# Altium schematic sheet LED Ring.SchDoc
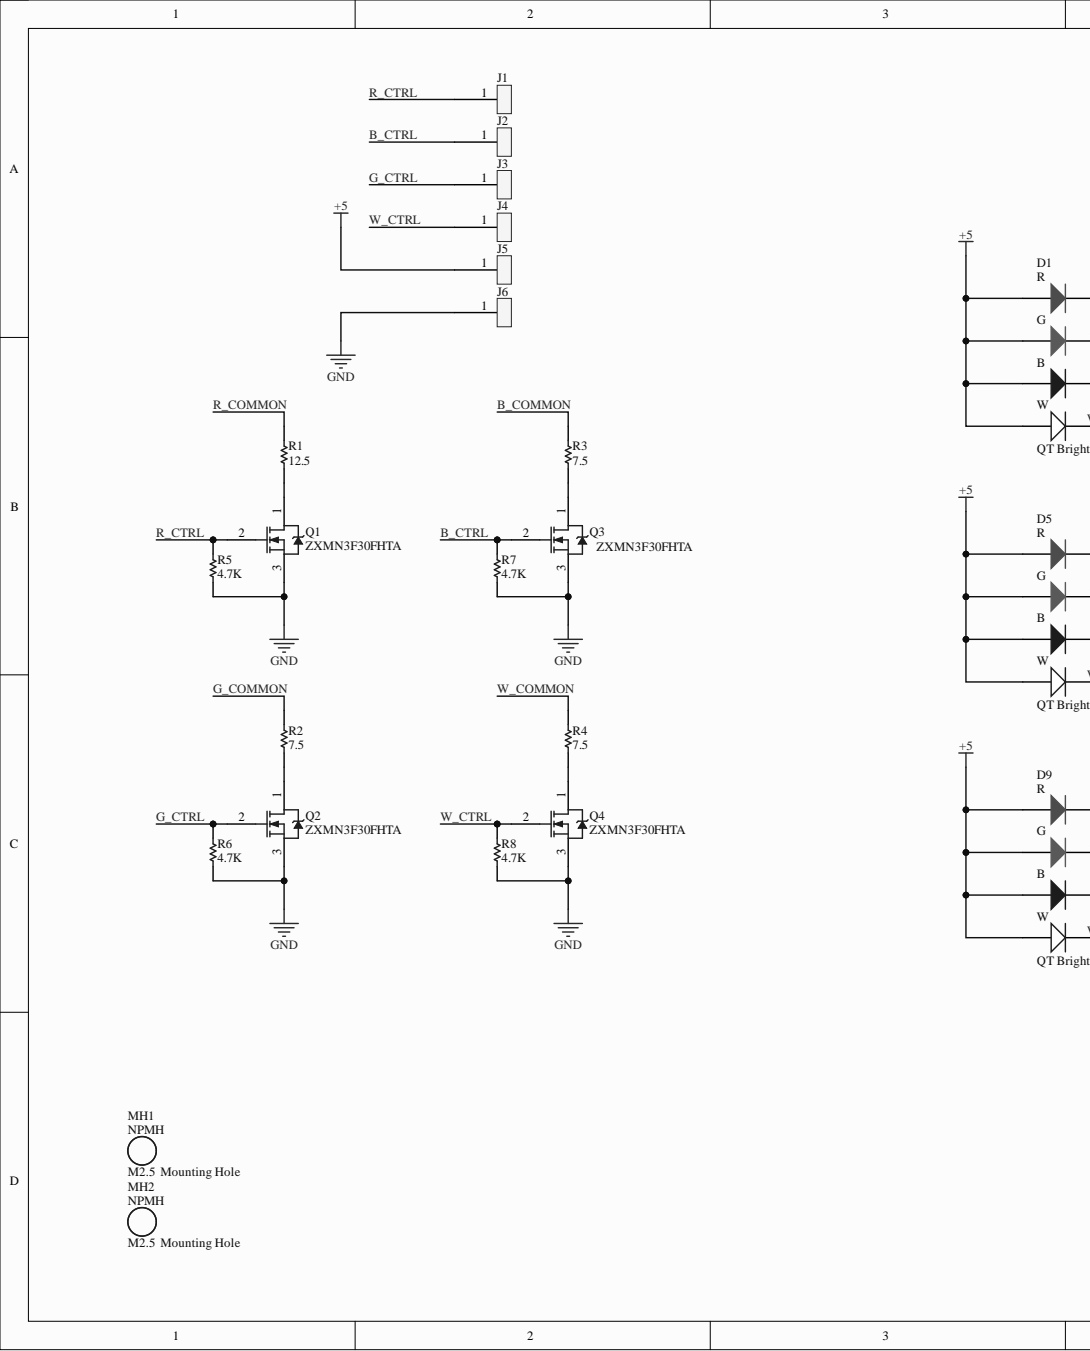
[diagram p0: LED Ring.SchDoc, left]
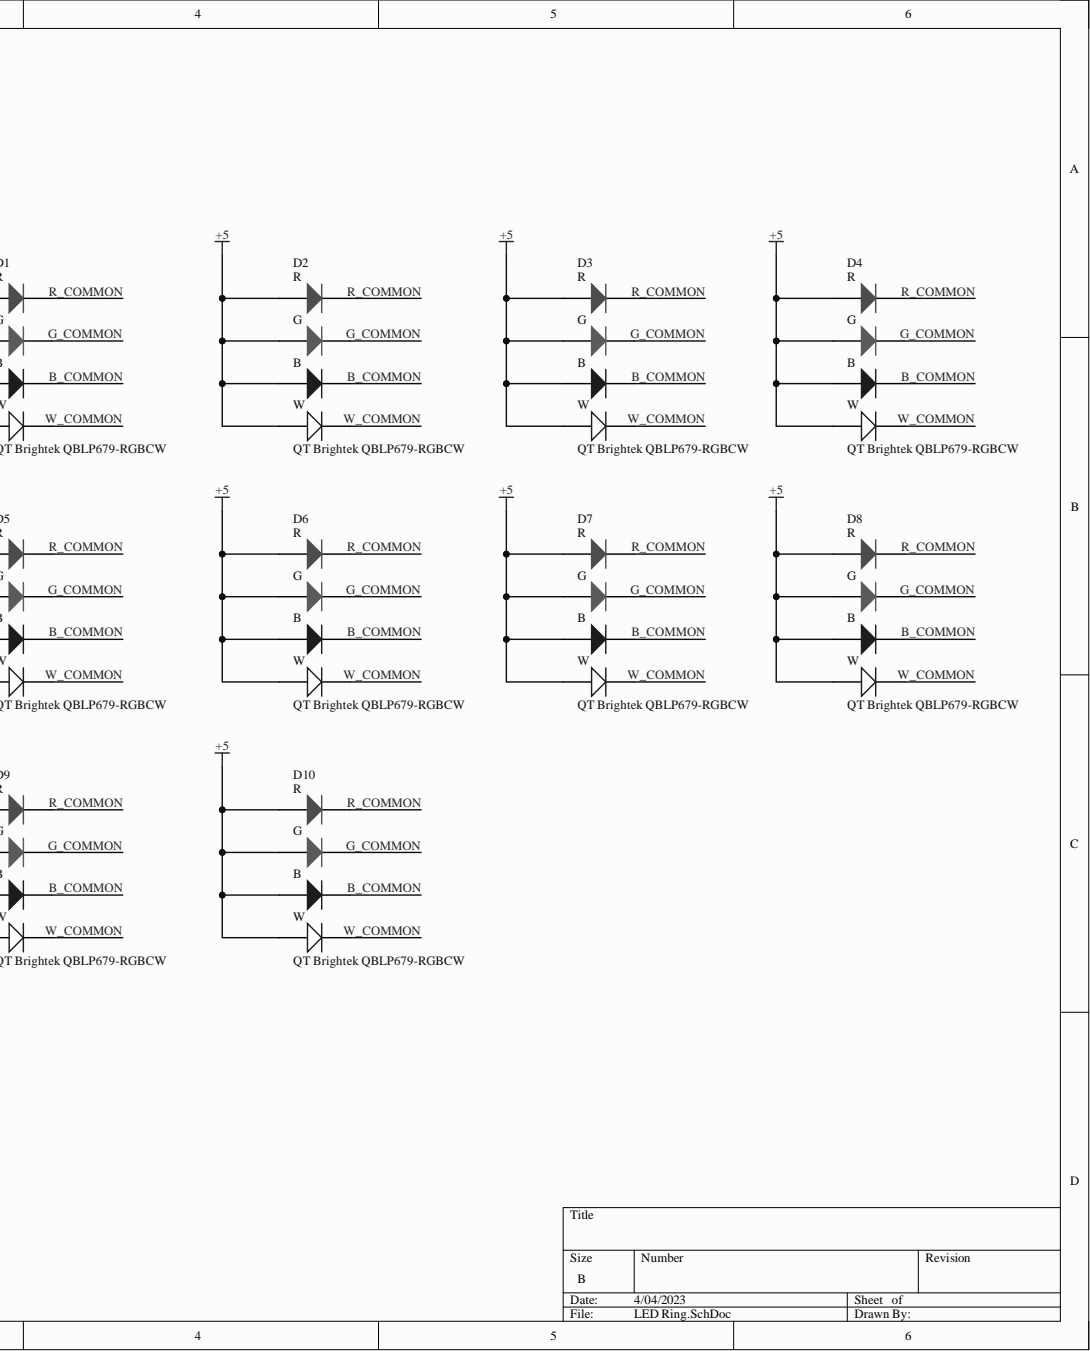
[diagram p1: LED Ring.SchDoc, right]

## Parts
D1  QT Brightek QBLP679-RGBCW  Manufacturer Part Number: QBLP679-RGBCW  [QT Brightek QBLP679-RGBCW-Footprint-1]
D2  QT Brightek QBLP679-RGBCW  Manufacturer Part Number: QBLP679-RGBCW  [QT Brightek QBLP679-RGBCW-Footprint-1]
D3  QT Brightek QBLP679-RGBCW  Manufacturer Part Number: QBLP679-RGBCW  [QT Brightek QBLP679-RGBCW-Footprint-1]
D4  QT Brightek QBLP679-RGBCW  Manufacturer Part Number: QBLP679-RGBCW  [QT Brightek QBLP679-RGBCW-Footprint-1]
D5  QT Brightek QBLP679-RGBCW  Manufacturer Part Number: QBLP679-RGBCW  [QT Brightek QBLP679-RGBCW-Footprint-1]
D6  QT Brightek QBLP679-RGBCW  Manufacturer Part Number: QBLP679-RGBCW  [QT Brightek QBLP679-RGBCW-Footprint-1]
D7  QT Brightek QBLP679-RGBCW  Manufacturer Part Number: QBLP679-RGBCW  [QT Brightek QBLP679-RGBCW-Footprint-1]
D8  QT Brightek QBLP679-RGBCW  Manufacturer Part Number: QBLP679-RGBCW  [QT Brightek QBLP679-RGBCW-Footprint-1]
D9  QT Brightek QBLP679-RGBCW  Manufacturer Part Number: QBLP679-RGBCW  [QT Brightek QBLP679-RGBCW-Footprint-1]
D10  QT Brightek QBLP679-RGBCW  Manufacturer Part Number: QBLP679-RGBCW  [QT Brightek QBLP679-RGBCW-Footprint-1]
J1  Solder Pad  [Solder Pad-Footprint-2]  (value not drawn)
J2  Solder Pad  [Solder Pad-Footprint-2]  (value not drawn)
J3  Solder Pad  [Solder Pad-Footprint-2]  (value not drawn)
J4  Solder Pad  [Solder Pad-Footprint-2]  (value not drawn)
J5  Solder Pad  [Solder Pad-Footprint-2]  (value not drawn)
J6  Solder Pad  [Solder Pad-Footprint-2]  (value not drawn)
MH1  M2.5  Mounting Hole  [M2.5  Mounting Hole-Footprint-1]
MH2  M2.5  Mounting Hole  [M2.5  Mounting Hole-Footprint-1]
Q1  ZXMN3F30FHTA  [PCB-6qjdqzw9o1g00o09vodq-1]
Q2  ZXMN3F30FHTA  [PCB-6qjdqzw9o1g00o09vodq-1]
Q3  ZXMN3F30FHTA  [PCB-6qjdqzw9o1g00o09vodq-1]
Q4  ZXMN3F30FHTA  [PCB-6qjdqzw9o1g00o09vodq-1]
R1  12.5  [PCB-0002-00354-1]
R2  7.5  [PCB-0002-00354-1]
R3  7.5  [PCB-0002-00354-1]
R4  7.5  [PCB-0002-00354-1]
R5  4.7K  [PCB-0002-00354-1]
R6  4.7K  [PCB-0002-00354-1]
R7  4.7K  [PCB-0002-00354-1]
R8  4.7K  [PCB-0002-00354-1]

## Nets
+5: D1.5 D1.6 D1.7 D1.8 D2.5 D2.6 D2.7 D2.8 D3.5 D3.6 D3.7 D3.8 D4.5 D4.6 D4.7 D4.8 D5.5 D5.6 D5.7 D5.8 D6.5 D6.6 D6.7 D6.8 D7.5 D7.6 D7.7 D7.8 D8.5 D8.6 D8.7 D8.8 D9.5 D9.6 D9.7 D9.8 D10.5 D10.6 D10.7 D10.8 J5.1
GND: J6.1 Q1.3 Q2.3 Q3.3 Q4.3 R5.1 R6.1 R7.1 R8.1
B_COMMON: D1.2 D2.2 D3.2 D4.2 D5.2 D6.2 D7.2 D8.2 D9.2 D10.2 R3.2
B_CTRL: J2.1 Q3.2 R7.2
G_COMMON: D1.3 D2.3 D3.3 D4.3 D5.3 D6.3 D7.3 D8.3 D9.3 D10.3 R2.2
G_CTRL: J3.1 Q2.2 R6.2
R_COMMON: D1.4 D2.4 D3.4 D4.4 D5.4 D6.4 D7.4 D8.4 D9.4 D10.4 R1.2
R_CTRL: J1.1 Q1.2 R5.2
W_COMMON: D1.1 D2.1 D3.1 D4.1 D5.1 D6.1 D7.1 D8.1 D9.1 D10.1 R4.2
W_CTRL: J4.1 Q4.2 R8.2
NetQ1_1: Q1.1 R1.1
NetQ2_1: Q2.1 R2.1
NetQ3_1: Q3.1 R3.1
NetQ4_1: Q4.1 R4.1

## Library data of the parts
D1 = CMP-81b54e06759c5e72-5: Led Rgbw Diffused 8PLCC SMD; ReplaceComponentId: NLGCQQLC; Component Kind: Standard; Digikey Part Number: 1516-QBLP679-RGBCWCT-ND; Digikey Url: https://www.digikey.com/en/products/detail/qt-brightek-qtb/QBLP679-RGBCW/13278847; Library Name: My Personal Space; Octopart Url: https://octopart.com/qblp679-rgbcw-qt+brightek-112841039; OctopartID: 81b54e06759c5e72; PartId: 1
D2 = CMP-81b54e06759c5e72-5: Led Rgbw Diffused 8PLCC SMD; ReplaceComponentId: LNENNGUC; Component Kind: Standard; Digikey Part Number: 1516-QBLP679-RGBCWCT-ND; Digikey Url: https://www.digikey.com/en/products/detail/qt-brightek-qtb/QBLP679-RGBCW/13278847; Library Name: My Personal Space; Octopart Url: https://octopart.com/qblp679-rgbcw-qt+brightek-112841039; OctopartID: 81b54e06759c5e72; PartId: 1
D3 = CMP-81b54e06759c5e72-5: Led Rgbw Diffused 8PLCC SMD; ReplaceComponentId: FQEBMTPW; Component Kind: Standard; Digikey Part Number: 1516-QBLP679-RGBCWCT-ND; Digikey Url: https://www.digikey.com/en/products/detail/qt-brightek-qtb/QBLP679-RGBCW/13278847; Library Name: My Personal Space; Octopart Url: https://octopart.com/qblp679-rgbcw-qt+brightek-112841039; OctopartID: 81b54e06759c5e72; PartId: 1
D4 = CMP-81b54e06759c5e72-5: Led Rgbw Diffused 8PLCC SMD; ReplaceComponentId: TTVRJRIF; Component Kind: Standard; Digikey Part Number: 1516-QBLP679-RGBCWCT-ND; Digikey Url: https://www.digikey.com/en/products/detail/qt-brightek-qtb/QBLP679-RGBCW/13278847; Library Name: My Personal Space; Octopart Url: https://octopart.com/qblp679-rgbcw-qt+brightek-112841039; OctopartID: 81b54e06759c5e72; PartId: 1
D5 = CMP-81b54e06759c5e72-5: Led Rgbw Diffused 8PLCC SMD; ReplaceComponentId: MXYZXPGO; Component Kind: Standard; Digikey Part Number: 1516-QBLP679-RGBCWCT-ND; Digikey Url: https://www.digikey.com/en/products/detail/qt-brightek-qtb/QBLP679-RGBCW/13278847; Library Name: My Personal Space; Octopart Url: https://octopart.com/qblp679-rgbcw-qt+brightek-112841039; OctopartID: 81b54e06759c5e72; PartId: 1
D6 = CMP-81b54e06759c5e72-5: Led Rgbw Diffused 8PLCC SMD; ReplaceComponentId: EKQCEWFC; Component Kind: Standard; Digikey Part Number: 1516-QBLP679-RGBCWCT-ND; Digikey Url: https://www.digikey.com/en/products/detail/qt-brightek-qtb/QBLP679-RGBCW/13278847; Library Name: My Personal Space; Octopart Url: https://octopart.com/qblp679-rgbcw-qt+brightek-112841039; OctopartID: 81b54e06759c5e72; PartId: 1
D7 = CMP-81b54e06759c5e72-5: Led Rgbw Diffused 8PLCC SMD; ReplaceComponentId: VZDWLDBB; Component Kind: Standard; Digikey Part Number: 1516-QBLP679-RGBCWCT-ND; Digikey Url: https://www.digikey.com/en/products/detail/qt-brightek-qtb/QBLP679-RGBCW/13278847; Library Name: My Personal Space; Octopart Url: https://octopart.com/qblp679-rgbcw-qt+brightek-112841039; OctopartID: 81b54e06759c5e72; PartId: 1
D8 = CMP-81b54e06759c5e72-5: Led Rgbw Diffused 8PLCC SMD; ReplaceComponentId: JXUHXOQN; Component Kind: Standard; Digikey Part Number: 1516-QBLP679-RGBCWCT-ND; Digikey Url: https://www.digikey.com/en/products/detail/qt-brightek-qtb/QBLP679-RGBCW/13278847; Library Name: My Personal Space; Octopart Url: https://octopart.com/qblp679-rgbcw-qt+brightek-112841039; OctopartID: 81b54e06759c5e72; PartId: 1
D9 = CMP-81b54e06759c5e72-5: Led Rgbw Diffused 8PLCC SMD; ReplaceComponentId: YUJXVNQK; Component Kind: Standard; Digikey Part Number: 1516-QBLP679-RGBCWCT-ND; Digikey Url: https://www.digikey.com/en/products/detail/qt-brightek-qtb/QBLP679-RGBCW/13278847; Library Name: My Personal Space; Octopart Url: https://octopart.com/qblp679-rgbcw-qt+brightek-112841039; OctopartID: 81b54e06759c5e72; PartId: 1
D10 = CMP-81b54e06759c5e72-5: Led Rgbw Diffused 8PLCC SMD; ReplaceComponentId: JXLEKMNO; Component Kind: Standard; Digikey Part Number: 1516-QBLP679-RGBCWCT-ND; Digikey Url: https://www.digikey.com/en/products/detail/qt-brightek-qtb/QBLP679-RGBCW/13278847; Library Name: My Personal Space; Octopart Url: https://octopart.com/qblp679-rgbcw-qt+brightek-112841039; OctopartID: 81b54e06759c5e72; PartId: 1
J1 = CMP-uvht148jqfrttjlcmgxr-4: ReplaceComponentId: EWAYNYGD; Component Kind: Standard; CustomComponentID: uvht148jqfrttjlcmgxr; Description: Solder Pad; Library Name: My Personal Space; PartId: 1
J2 = CMP-uvht148jqfrttjlcmgxr-4: ReplaceComponentId: JHNNVWFI; Component Kind: Standard; CustomComponentID: uvht148jqfrttjlcmgxr; Description: Solder Pad; Library Name: My Personal Space; PartId: 1
J3 = CMP-uvht148jqfrttjlcmgxr-4: ReplaceComponentId: CZQUPCPW; Component Kind: Standard; CustomComponentID: uvht148jqfrttjlcmgxr; Description: Solder Pad; Library Name: My Personal Space; PartId: 1
J4 = CMP-uvht148jqfrttjlcmgxr-4: ReplaceComponentId: POTMEGDM; Component Kind: Standard; CustomComponentID: uvht148jqfrttjlcmgxr; Description: Solder Pad; Library Name: My Personal Space; PartId: 1
J5 = CMP-uvht148jqfrttjlcmgxr-4: ReplaceComponentId: NOXMEJXH; Component Kind: Standard; CustomComponentID: uvht148jqfrttjlcmgxr; Description: Solder Pad; Library Name: My Personal Space; PartId: 1
J6 = CMP-uvht148jqfrttjlcmgxr-4: ReplaceComponentId: DKYUVQKR; Component Kind: Standard; CustomComponentID: uvht148jqfrttjlcmgxr; Description: Solder Pad; Library Name: My Personal Space; PartId: 1
MH1 MH2 = CMP-u8vwlyks1x9lkf1x42a9-2: M2.5 Mounting Through Hole; ReplaceComponentId: AITYREHL; Component Kind: Standard; CustomComponentID: u8vwlyks1x9lkf1x42a9; Library Name: My Personal Space; PartId: 1
Q1-Q4 = CMP-cdff043d5a861238-1: ZXMN3F30 Series 30 V 0.047 Ohm N-Channel Enhancement Mode MOSFET - SOT-23; Continuous Drain Current (Ids): 4.60 A; Lead-Free Status: Lead Free; Power Dissipation: 1.40 W; Case/Package: TO-236, SC-59, SOT-23-3; Component Kind: Standard; Library Name: My Personal Space; Lifecycle Status: Active; Manufacturer Part Number: ZXMN3F30FHTA; Mounting Style: Surface Mount; Number of Pins: 3; Octopart URL: http://octopart.com/zxmn3f30fhta-diodes+inc.-13152799; OctopartID: cdff043d5a861238; Packaging: Cut Tape (CT); Polarity: N-Channel; REACH SVHC Compliance: No SVHC; RoHS: Compliant
R1-R8 = CMP-19463543-5: PANASONIC   ERJP6WF1200V   RESISTOR, SURGE, 0805, 120R, 1%; Component Kind: Standard; Library Name: My Personal Space
